# Altium schematic sheet 28Pins Schematic.SchDoc("28Pins Schematic") (continued)

## Library data of the parts
C1-C3 C5-C14 C16 C19 C20 C26-C28 = C2012X7R1H104K085AA: TDK - C2012X7R1H104K085AA - Keramikvielschichtkondensator, SMD, 0.1 µF, 50 V, 0805 [Metrisch 2012], ± 10%, X7R, C Series TDK; PCN Part Number: MLCC Part Number Change 30/Nov/2012; Product Training Modules: C Series General Applications SEAT, CCV, and TVCL Design Tools Guide to Replacing an Electrolytic Capacitor with an MLCC; Other Names: 445-7534-1; Online Catalog: C Series, General Purpose; Kits: 445-9015-KIT-ND  - KIT MLCC 1000PF-47UF 0805 10-50V; Capacitance: 0.1µF; Tolerance: ±10%; Thickness (Max): 0.037" (0.95mm); Temperature Coefficient: X7R; Supplier Part Number 1: 445-7534-1-ND; Supplier 1: Digi-Key; Stock 1: 148807; Standard Package: 1; Size / Dimension: 0.079" L x 0.049" W (2.00mm x 1.25mm); Series: C; RoHS Information: RoHS Certificate-MLCC; Ratings: -; Pricing 1: 1=0.17, 10=0.119, 100=0.0561, 500=0.0394, 1000=0.0326 (USD); Packaging: Cut Tape (CT); Package / Case: 0805 (2012 Metric); Operating Temperature: -55°C ~ 125°C; Mounting Type: Surface Mount, MLCC; Manufacturer Part Number: C2012X7R1H104K085AA; Manufacturer: TDK; Library Name: Arduino_V01.SchLib; Lead Style: -; Lead Spacing: -; Height - Seated (Max): -; Features: -; Family: Ceramic Capacitors; ComponentLink5URL: http://www.farnell.com/datasheets/1571323.pdf?src-supplier=Element14; ComponentLink5Description: http://www.farnell.com/datasheets/1571323.pdf?src-supplier=Element14; ComponentLink4URL: http://www.farnell.com/datasheets/1796654.pdf?src-supplier=Newark; ComponentLink4Description: http://www.farnell.com/datasheets/1796654.pdf?src-supplier=Newark; ComponentLink3URL: http://www.tdk.co.jp/tefe02/e412_c.pdf?src-supplier=Future+Electronics; ComponentLink3Description: http://www.tdk.co.jp/tefe02/e412_c.pdf?src-supplier=Future+Electronics; ComponentLink2URL: https://product.tdk.com/info/en/catalog/datasheets/mlcc_commercial_general_en.pdf?src-supplier=Digi-Key; ComponentLink2Description: https://product.tdk.com/info/en/catalog/datasheets/mlcc_commercial_general_en.pdf?src-supplier=Digi-Key; ComponentLink1URL: http://uk.rs-online.com/webdocs/1532/0900766b81532cd0.pdf?src-supplier=RSComponents; ComponentLink1Description: http://uk.rs-online.com/webdocs/1532/0900766b81532cd0.pdf?src-supplier=RSComponents; Component Kind: Standard; Category: Capacitors; Applications: General Purpose
  C5 C19 C26: Voltage - Rated: 50V
C4 C15 C17 C18 C23-C25 = CGA4J1X5R1C106M125AC: Automotive Grade MLCC, 0805, X5R, 16V, 10 F, 20%, 1.25mm; PCN Part Number: MLCC Part Number Change 30/Nov/2012; Manufacturer: TDK; Product Training Modules: CGA Series for Automotive Applications SEAT, CCV, and TVCL Design Tools Guide to Replacing an Electrolytic Capacitor with an MLCC; Other Names: 445-12654-1; Online Catalog: CGA Series, Automotive General, Mid and High Voltage; Featured Product: CGA Series MLCC Capacitors; EDA / CAD Models: Download from Accelerated Designs; Capacitance: 10µF; Tolerance: ±20%; Thickness (Max): 0.057" (1.45mm); Temperature Coefficient: X5R; Supplier Part Number 1: 445-12654-1-ND; Supplier 1: Digi-Key; Stock 1: 6740; Standard Package: 1; Size / Dimension: 0.079" L x 0.049" W (2.00mm x 1.25mm); Series: CGA; RoHS Information: CGA Series, RoHS Cert of Compliance; Ratings: AEC-Q200; Pricing 1: 1=0.52, 10=0.362, 100=0.2174, 500=0.163, 1000=0.1377 (USD); Packaging: Cut Tape (CT); Package / Case: 0805 (2012 Metric); Operating Temperature: -55°C ~ 85°C; Mounting Type: Surface Mount, MLCC; Manufacturer Part Number: CGA4J1X5R1C106M125AC; Library Name: Arduino_V01.SchLib; Family: Ceramic Capacitors; ComponentLink4URL: http://product.tdk.com/en/catalog/datasheets/mlcc_automotive_general_en.pdf?src-supplier=Avnet; ComponentLink4Description: http://product.tdk.com/en/catalog/datasheets/mlcc_automotive_general_en.pdf?src-supplier=Avnet; ComponentLink3URL: http://product.tdk.com/capacitor/mlcc/detailed_information.php?lang=en&ref=jp&part_no=CGA4J1X5R1C106M125AC&src-supplier=Mouser; ComponentLink3Description: http://product.tdk.com/capacitor/mlcc/detailed_information.php?lang=en&ref=jp&part_no=CGA4J1X5R1C106M125AC&src-supplier=Mouser; ComponentLink2URL: https://product.tdk.com/info/en/documents/chara_sheet/CGA4J1X5R1C106M125AC.pdf?src-supplier=Digi-Key; ComponentLink2Description: https://product.tdk.com/info/en/documents/chara_sheet/CGA4J1X5R1C106M125AC.pdf?src-supplier=Digi-Key; ComponentLink1URL: https://product.tdk.com/info/en/catalog/datasheets/mlcc_automotive_general_en.pdf?src-supplier=Digi-Key; ComponentLink1Description: https://product.tdk.com/info/en/catalog/datasheets/mlcc_automotive_general_en.pdf?src-supplier=Digi-Key; Component Kind: Standard; Category: Capacitors; Applications: Automotive
  C18 C23 C25: Voltage - Rated: 16V
C21 C22 C32 C33 = C0805C180J5GACTU: CAP CER 18PF 50V C0G/NP0 0805; Manufacturer: KEMET; Voltage: 50 Vdc; Tolerance: 5 %; Product Length: 2 mm; Product Height: 0.78 mm; Product Depth: 1.25 mm; Dielectric: C0G; Supplier Part Number 1: 399-1112-1-ND; Supplier 1: Digi-Key; Stock 1: 16285; Pricing 1: 1=0.14, 10=0.1, 100=0.0468, 500=0.0328, 1000=0.0272 (USD); Package/Case: 0805; Operating Temperature: -55 to 125 °C; Mounting: Surface Mount; Manufacturer Part Number: C0805C180J5GACTU; Library Name: Arduino_V01.SchLib; Construction: Flat; ComponentLink3URL: https://www.verical.com/server-webapp/html/getDatasheet?partID=759702&src-supplier=Verical; ComponentLink3Description: https://www.verical.com/server-webapp/html/getDatasheet?partID=759702&src-supplier=Verical; ComponentLink2URL: https://www.verical.com/server-webapp/html/getDatasheet?partID=385668&src-supplier=Verical; ComponentLink2Description: https://www.verical.com/server-webapp/html/getDatasheet?partID=385668&src-supplier=Verical; ComponentLink1URL: http://www.farnell.com/datasheets/1769375.pdf?src-supplier=Element14; ComponentLink1Description: http://www.farnell.com/datasheets/1769375.pdf?src-supplier=Element14; Component Kind: Standard; Category: Capacitor Ceramic Multilayer; Capacitance Value: 18 pF
C29 = CGA4J3X7R1E105K125AB: CAP CER 1UF 25V X7R 0805; PCN Part Number: MLCC Part Number Change 30/Nov/2012; Manufacturer: TDK; Tolerance: ±10%; Product Training Modules: CGA Series for Automotive Applications SEAT, CCV, and TVCL Design Tools Guide to Replacing an Electrolytic Capacitor with an MLCC; Other Names: 445-5687-6; Online Catalog: CGA Series, Automotive General, Mid and High Voltage; Featured Product: CGA Series MLCC Capacitors; Capacitance: 1µF; Thickness (Max): 0.057" (1.45mm); Temperature Coefficient: X7R; Supplier Part Number 1: 445-5687-1-ND; Supplier 1: Digi-Key; Stock 1: 9208; Standard Package: 1; Size / Dimension: 0.079" L x 0.049" W (2.00mm x 1.25mm); Series: CGA; RoHS Information: RoHS Certificate-MLCC; Ratings: AEC-Q200; Pricing 1: 1=0.24, 10=0.163, 100=0.0826, 500=0.0565, 1000=0.05 (USD); Packaging: Digi-Reel®; Package / Case: 0805 (2012 Metric); Operating Temperature: -55°C ~ 125°C; Mounting Type: Surface Mount, MLCC; Manufacturer Part Number: CGA4J3X7R1E105K125AB; Library Name: Arduino_V01.SchLib; Lead Style: -; Lead Spacing: -; Height - Seated (Max): -; Features: -; Family: Ceramic Capacitors; ComponentLink1URL: http://www.mouser.com/ds/2/400/lcc_automotive_general_en-843974.pdf?src-supplier=Mouser; ComponentLink1Description: http://www.mouser.com/ds/2/400/lcc_automotive_general_en-843974.pdf?src-supplier=Mouser; Component Kind: Standard; Category: Capacitors; Applications: Automotive
C30 C31 = EEE-1AA101WR: Cap Aluminum 100uF 10V 20% (5 X 5.4mm) SMD 50mA 1000 hr 85°C T/R; Manufacturer: Panasonic; Type: Can; Lifetime@Temperature: 2000 Hrs @ 85°C; Capacitance: 100 µF; Supplier Part Number 1: PCE3867CT-ND; Supplier 1: Digi-Key; Stock 1: 100201; Series: S; Ripple Current: 50 mA; Rated Voltage: 10 V; Pricing 1: 1=0.32, 10=0.221, 100=0.1328, 500=0.0996 (USD); Operating Temp Range: -40 to +85 °C; Operating Temp-Max: 85 °C; Manufacturer Part Number: EEE-1AA101WR; Library Name: Arduino_V01.SchLib; ComponentLink5URL: https://industrial.panasonic.com/cdbs/www-data/pdf/RDE0000/DME0000COL90.pdf?src-supplier=Verical; ComponentLink5Description: https://industrial.panasonic.com/cdbs/www-data/pdf/RDE0000/DME0000COL90.pdf?src-supplier=Verical; ComponentLink4URL: https://industrial.panasonic.com/cdbs/www-data/pdf/RDE0000/DME0000COL90.pdf?src-supplier=Allied+Electronics; ComponentLink4Description: https://industrial.panasonic.com/cdbs/www-data/pdf/RDE0000/DME0000COL90.pdf?src-supplier=Allied+Electronics; ComponentLink3URL: http://industrial.panasonic.com/www-data/pdf/ABA0000/ABA0000CE2.pdf?src-supplier=Future+Electronics; ComponentLink3Description: http://industrial.panasonic.com/www-data/pdf/ABA0000/ABA0000CE2.pdf?src-supplier=Future+Electronics; ComponentLink2URL: https://industrial.panasonic.com/cdbs/www-data/pdf/RDE0000/DME0000COL90.pdf?src-supplier=Mouser; ComponentLink2Description: https://industrial.panasonic.com/cdbs/www-data/pdf/RDE0000/DME0000COL90.pdf?src-supplier=Mouser; ComponentLink1URL: https://industrial.panasonic.com/cdbs/www-data/pdf/RDE0000/DME0000COL90.pdf?src-supplier=Avnet; ComponentLink1Description: https://industrial.panasonic.com/cdbs/www-data/pdf/RDE0000/DME0000COL90.pdf?src-supplier=Avnet; Component Kind: Standard; Case Size/Dimension: Ø 5 x 5.4 mm
D1 D3 = NSVBAT54HT1G: Schottky Diodes & Rectifiers SS SCHOTTKY DIODE; Manufacturer: ON Semiconductor; Voltage - Forward (Vf) (Max) @ If: 800mV @ 100mA; Voltage - DC Reverse (Vr) (Max): 30V; Speed: Small Signal =; Reverse Recovery Time (trr): 5ns; Current - Reverse Leakage @ Vr: 2µA @ 25V; Current - Average Rectified (Io): 200mA (DC); Capacitance @ Vr, F: 10pF @ 1V, 1MHz; Supplier Part Number 1: NSVBAT54HT1GOSCT-ND; Supplier Device Package: SOD-323; Supplier 1: Digi-Key; Stock 1: 10770; Standard Package: 3,000; Pricing 1: 1=0.48, 10=0.362, 25=0.3268, 100=0.1723, 250=0.1705, 500=0.1527, 1000=0.1188 (USD); Packaging: Tape & Reel (TR); Package / Case: SC-76, SOD-323; Mounting Type: Surface Mount; Manufacturer Part Number: NSVBAT54HT1G; Library Name: Arduino_V01.SchLib; Family: Diodes, Rectifiers - Single; Diode Type: Schottky; ComponentLink2URL: http://www.onsemi.com/pub_link/Collateral/BAT54HT1-D.PDF?src-supplier=Avnet+Europe; ComponentLink2Description: http://www.onsemi.com/pub_link/Collateral/BAT54HT1-D.PDF?src-supplier=Avnet+Europe; ComponentLink1URL: http://www.onsemi.com/pub/Collateral/BAT54HT1-D.PDF?src-supplier=Digi-Key; ComponentLink1Description: http://www.onsemi.com/pub/Collateral/BAT54HT1-D.PDF?src-supplier=Digi-Key; Component Kind: Standard; Category: Discrete Semiconductor Products
D2 D5 D6 = LOL29K-H2K1-24-Z: OSRAM - LO L29K-H2K1-24-Z - SMARTLED 0603 ORANGE; Manufacturer: Osram Opto; Wavelength - Peak: 610nm; Wavelength - Dominant: 606nm; Voltage - Forward (Vf) (Typ): 1.8V; Viewing Angle: 160°; Millicandela Rating: 6.3mcd; Lens Transparency: Diffused; Lens Style: Rectangle with Flat Top; Lens Size: 1.30mm x 0.80mm; Lens Color: White; Current - Test: 2mA; Configuration: Standard; Categories: Optoelectronics; Supplier Part Number 1: 475-2740-2-ND; Supplier Device Package: 0603; Supplier 1: Digi-Key; Stock 1: 0; Size / Dimension: 1.70mm L x 0.80mm W; Series: SmartLED; Part Status: Active; Packaging: Digi-Reel®; Package / Case: 0603 (1608 Metric); Mounting Type: Surface Mount; Manufacturer Part Number: LOL29K-H2K1-24-Z; Library Name: Arduino_V01.SchLib; Height (Max): 0.65mm; Features: -; ComponentLink2URL: https://www.verical.com/server-webapp/html/getDatasheet?partID=693885&src-supplier=Verical; ComponentLink2Description: https://www.verical.com/server-webapp/html/getDatasheet?partID=693885&src-supplier=Verical; ComponentLink1URL: https://dammedia.osram.info/media/resource/hires/osram-dam-2493882/LO%20L29K.pdf?src-supplier=Digi-Key; ComponentLink1Description: https://dammedia.osram.info/media/resource/hires/osram-dam-2493882/LO%20L29K.pdf?src-supplier=Digi-Key; Component Kind: Standard; Color: Orange
D4 = LGL29K-F2J1-24-Z: LED Uni-Color Green 572nm Automotive 2-Pin Chip 0603(1608Metric) T/R; Manufacturer: Osram Opto; Wavelength - Dominant: 570nm; Voltage - Forward (Vf) (Typ): 1.7V; Viewing Angle: 160°; Millicandela Rating: 3.5mcd; Lens Transparency: Diffused; Lens Style: Rectangle with Flat Top; Lens Size: 1.30mm x 0.80mm; Lens Color: White; Current - Test: 2mA; Configuration: Standard; Categories: Optoelectronics; Wavelength - Peak: 572nm; Supplier Part Number 1: 475-3118-1-ND; Supplier Device Package: 0603; Supplier 1: Digi-Key; Stock 1: 0; Size / Dimension: 1.70mm L x 0.80mm W; Series: SmartLED; Part Status: Active; Packaging: Digi-Reel®; Package / Case: 0603 (1608 Metric); Mounting Type: Surface Mount; Manufacturer Part Number: LGL29K-F2J1-24-Z; Library Name: Arduino_V01.SchLib; Height (Max): 0.65mm; Features: -; ComponentLink5URL: http://catalog.osram-os.com/catalogue/catalogue.do;jsessionid=3DE84243E85F820603DAFD5199D8DDA3?act=downloadFile&favOid=020000020000138e000100b6&src-supplier=Avnet+Europe; ComponentLink5Description: http://catalog.osram-os.com/catalogue/catalogue.do;jsessionid=3DE84243E85F820603DAFD5199D8DDA3?act=downloadFile&favOid=020000020000138e000100b6&src-supplier=Avnet+Europe; ComponentLink4URL: https://dammedia.osram.info/media/resource/hires/osram-dam-2493945/LG%20L29K.pdf?src-supplier=Digi-Key; ComponentLink4Description: https://dammedia.osram.info/media/resource/hires/osram-dam-2493945/LG%20L29K.pdf?src-supplier=Digi-Key; ComponentLink3URL: http://components.arrow.com/part/dataview/0323_02006818?src-supplier=Arrow+Europe; ComponentLink3Description: http://components.arrow.com/part/dataview/0323_02006818?src-supplier=Arrow+Europe; ComponentLink2URL: http://components.arrow.com/part/dataview/41971649S9781339N2128?src-supplier=Arrow; ComponentLink2Description: http://components.arrow.com/part/dataview/41971649S9781339N2128?src-supplier=Arrow; ComponentLink1URL: http://catalog.osram-os.com/catalogue/catalogue.do;jsessionid=3DE84243E85F820603DAFD5199D8DDA3?act=downloadFile&favOid=020000020000138e000100b6&src-supplier=Avnet; ComponentLink1Description: http://catalog.osram-os.com/catalogue/catalogue.do;jsessionid=3DE84243E85F820603DAFD5199D8DDA3?act=downloadFile&favOid=020000020000138e000100b6&src-supplier=Avnet; Component Kind: Standard; Color: Green
FB1-FB3 = MPZ2012S601AT000: FERRITE BEAD 600 OHM 0805 1LN; PCN Part Number: MMZ,MPS Series Oct/2014; Manufacturer: TDK; Product Training Modules: EMC Components; Other Names: 445-2206-6; Online Catalog: MPZ2012 Series; Impedance @ Frequency: 600 Ohm @ 100MHz; Filter Type: Differential Mode - Single; DC Resistance (DCR): 100 mOhm Max; Current Rating: 2A; Catalog Drawings: (MMZ,MPZ)2012 Series Top    (MMZ,MPZ)2012 Series Footprint    (MMZ,MPZ)2012 Series Side; Supplier Part Number 1: 445-2206-1-ND; Supplier 1: Digi-Key; Stock 1: 137805; Standard Package: 1; Size / Dimension: 0.079" L x 0.049" W (2.00mm x 1.25mm); Series: MPZ; Pricing 1: 1=0.11, 10=0.091, 25=0.0704, 50=0.0584, 100=0.0503, 250=0.0403, 500=0.0362, 1000=0.0302 (USD); Packaging: Digi-Reel®; Package / Case: 0805 (2012 Metric); Mounting Type: Surface Mount; Manufacturer Part Number: MPZ2012S601AT000; Library Name: Arduino_V01.SchLib; Height (Max): 0.041" (1.05mm); Family: Ferrite Beads and Chips; ComponentLink2URL: https://product.tdk.com/info/en/catalog/datasheets/beads_commercial_power_mpz2012_en.pdf?src-supplier=Digi-Key; ComponentLink2Description: https://product.tdk.com/info/en/catalog/datasheets/beads_commercial_power_mpz2012_en.pdf?src-supplier=Digi-Key; ComponentLink1URL: https://media.digikey.com/pdf/Data%20Sheets/TDK%20PDFs/MPZ_Series_Br.pdf?src-supplier=Digi-Key; ComponentLink1Description: https://media.digikey.com/pdf/Data%20Sheets/TDK%20PDFs/MPZ_Series_Br.pdf?src-supplier=Digi-Key; Component Kind: Standard; Category: Filters
FID1-FID4 = FID_1MM: Fiducial 40mil diameter, 120mil mask; Library Name: Arduino_V01.SchLib
J1 J7 = M20-7820842: 2.54mm (0.1") Pitch SIL Vertical PC Tail Socket Assembly, selective gold + tin, 8 contacts; Manufacturer: Harwin; Rad Hard: No; Number of Contacts: 8; Gender: SKT; Type: Socket Strip; Termination Method: Solder; Supplier Part Number 1: 952-1823-ND; Supplier 1: Digi-Key; Stock 1: 0; Pricing 1: 1=1.1, 10=0.972, 100=0.8382, 500=0.6858, 1000=0.6096, 5000=0.5334, 10000=0.5067 (USD); Pitch: 2.54 mm; Number of Rows: 1; Manufacturer Part Number: M20-7820842; Library Name: Arduino_V01.SchLib; Contact Plating: Gold; ComponentLink2URL: http://www.harwin.com/include/downloads/drawings/M20-782.PDF?src-supplier=Avnet; ComponentLink2Description: http://www.harwin.com/include/downloads/drawings/M20-782.PDF?src-supplier=Avnet; ComponentLink1URL: https://cdn.harwin.com/pdfs/Harwin_PC_Connectors_M20.pdf?src-supplier=Avnet+Europe; ComponentLink1Description: https://cdn.harwin.com/pdfs/Harwin_PC_Connectors_M20.pdf?src-supplier=Avnet+Europe; Component Kind: Standard; Category: Connector Headers and PCB Receptacles
J2 J3 = 67997-206HLF: CONN HEADER VERT 6POS 2.54MM; Manufacturer: Amphenol FCI; Voltage Rating: 1.5 kV; Number of Positions / Contacts: 6; Mounting Angle: Vertical; Mating Post Length: 5.84 mm; Insulation Resistance: 5000 MOhms; Current Rating: 3 A; Brand: FCI; Type: Unshrouded; Termination Style: Through Hole; Termination Post Length: 3.05 mm; Supplier Part Number 1: 609-3234-ND; Supplier 1: Digi-Key; Stock 1: 14300; Series: 67997; RoHS: Details; Product Type: Headers; Product Category: Headers & Wire Housings; Pricing 1: 1=0.33, 10=0.316, 100=0.2212, 500=0.1896, 1000=0.158, 5000=0.1355, 10000=0.1264 (USD); Pitch: 2.54 mm; Packaging: Bulk; Operating Temperature Range: - 65 C to + 125 C; Number of Rows: 2; Number of Positions: 6; Mounting Style: Through Hole; Manufacturer Part Number: 67997-206HLF; Library Name: Arduino_V01.SchLib; Housing Material: Thermoplastic; Flammability Rating: UL 94 V-0; Factory Pack Quantity: 100; Contact Plating: Gold/GTX; Contact Material: Phosphor Bronze; Contact Gender: Pin (Male); ComponentLink3URL: https://cdn.amphenol-icc.com/media/wysiwyg/files/drawing/67996.pdf?src-supplier=Digi-Key; ComponentLink3Description: https://cdn.amphenol-icc.com/media/wysiwyg/files/drawing/67996.pdf?src-supplier=Digi-Key; ComponentLink2URL: http://portal.fciconnect.com/Comergent/fci/drawing/67996.pdf?src-supplier=Avnet; ComponentLink2Description: http://portal.fciconnect.com/Comergent/fci/drawing/67996.pdf?src-supplier=Avnet; ComponentLink1URL: http://portal.fciconnect.com/Comergent/adirect/fci?cmd=catCustomerDrawing&productName=67997-206HLF&src-supplier=Mouser; ComponentLink1Description: http://portal.fciconnect.com/Comergent/adirect/fci?cmd=catCustomerDrawing&productName=67997-206HLF&src-supplier=Mouser; Component Kind: Standard
J4 = M20-7821046: HARWIN - M20-7821046 - Board-To-Board Connector, M20 Series, Through Hole, Receptacle, 10, 2.54 mm, Tin Plated Contacts; Number of Contacts: 10; Current Rating: 3A; Body Orientation: Straight; Type: Socket Strip; Termination Method: Solder; Supplier Part Number 1: 952-1847-ND; Supplier 1: Digi-Key; Stock 1: 499; Pricing 1: 1=1.28, 10=1.122, 100=0.968, 500=0.792, 1000=0.704, 5000=0.616, 10000=0.5852 (USD); Pitch: 2.54mm; Number of Rows: 1; Mounting Type: Through Hole; Manufacturer Part Number: M20-7821046; Manufacturer: Harwin; Library Name: Arduino_V01.SchLib; Contact Material: Phosphor Bronze; ComponentLink5URL: http://uk.rs-online.com/webdocs/1422/0900766b81422659.pdf?src-supplier=RSComponents; ComponentLink5Description: http://uk.rs-online.com/webdocs/1422/0900766b81422659.pdf?src-supplier=RSComponents; ComponentLink4URL: https://cdn.harwin.com/pdfs/Harwin_PC_Connectors_M20.pdf?src-supplier=Avnet+Europe; ComponentLink4Description: https://cdn.harwin.com/pdfs/Harwin_PC_Connectors_M20.pdf?src-supplier=Avnet+Europe; ComponentLink3URL: http://www.harwin.com/include/downloads/drawings/M20-782.PDF?src-supplier=Avnet; ComponentLink3Description: http://www.harwin.com/include/downloads/drawings/M20-782.PDF?src-supplier=Avnet; ComponentLink2URL: https://cdn.harwin.com/pdfs/M20-782.pdf?src-supplier=Digi-Key; ComponentLink2Description: https://cdn.harwin.com/pdfs/M20-782.pdf?src-supplier=Digi-Key; ComponentLink1URL: https://www.verical.com/server-webapp/html/getDatasheet?partID=559226&src-supplier=Verical; ComponentLink1Description: https://www.verical.com/server-webapp/html/getDatasheet?partID=559226&src-supplier=Verical; Component Kind: Standard
J5 = M20-7820642: Conn Socket Strip SKT 6 POS 2.54mm Solder ST Thru-Hole Tube; Manufacturer: Harwin; Number of Contacts: 6; Type: Socket Strip; Termination Method: Solder; Supplier Part Number 1: 952-1808-ND; Supplier 1: Digi-Key; Stock 1: 1120; Pricing 1: 1=0.99, 10=0.87, 100=0.7502, 500=0.6138, 1000=0.5456, 5000=0.4774, 10000=0.4535 (USD); Pitch: 2.54 mm; Number of Rows: 1; Manufacturer Part Number: M20-7820642; Library Name: Arduino_V01.SchLib; Gender: SKT; Contact Plating: Gold; ComponentLink4URL: http://components.arrow.com/part/dataview/0323_00725895?src-supplier=Arrow+Europe; ComponentLink4Description: http://components.arrow.com/part/dataview/0323_00725895?src-supplier=Arrow+Europe; ComponentLink3URL: http://www.harwin.com/include/downloads/pdfs/60PAGE140.PDF?src-supplier=Avnet; ComponentLink3Description: http://www.harwin.com/include/downloads/pdfs/60PAGE140.PDF?src-supplier=Avnet; ComponentLink2URL: https://cdn.harwin.com/pdfs/M20-782.pdf?src-supplier=Digi-Key; ComponentLink2Description: https://cdn.harwin.com/pdfs/M20-782.pdf?src-supplier=Digi-Key; ComponentLink1URL: http://www.mouser.com/ds/2/181/Harwin_Product_Catalog_page_197-1134272.pdf?src-supplier=Mouser; ComponentLink1Description: http://www.mouser.com/ds/2/181/Harwin_Product_Catalog_page_197-1134272.pdf?src-supplier=Mouser; Component Kind: Standard; Category: Connector Headers and PCB Receptacles
J6 J8 = PAD_WITH_HOLE_4MMx1.5MM: Library Name: Arduino_V01.SchLib; Description: PAD_WITH_HOLE_4MMx1.5MM
J9 = 68602-204HLF: CONN HEADER VERT 4POS 2.54MM; Manufacturer: Amphenol FCI; Rad Hard: No; Number of Contacts: 4; Type: Unshrouded Header; Termination Method: Solder; Supplier Part Number 1: 609-3369-ND; Supplier 1: Digi-Key; Stock 1: 655; Pricing 1: 1=0.34, 10=0.319, 100=0.2232, 500=0.1913, 1000=0.1594, 5000=0.1367, 10000=0.1275 (USD); Pitch: 2.54 mm; Packaging: Bulk; Number of Rows: 2; Manufacturer Part Number: 68602-204HLF; Library Name: Arduino_V01.SchLib; Contact Plating: Gold Over Nickel|GXT Over Nickel; ComponentLink1URL: https://cdn.amphenol-icc.com/media/wysiwyg/files/drawing/67996.pdf?src-supplier=Digi-Key; ComponentLink1Description: https://cdn.amphenol-icc.com/media/wysiwyg/files/drawing/67996.pdf?src-supplier=Digi-Key; Component Kind: Standard; Category: Connector Headers and PCB Receptacles
J10 = 10118193-0001LF: CONN USB MICRO B RECPT SMT R/A; Manufacturer: Amphenol FCI; Number of Contacts: 5 Contact; Mounting Angle: Right; Insulation Resistance: 1000 MOhms; Gender: Female; Current Rating: 1.8 A; Connector Type: Micro USB Type B receptacle; Brand: FCI; Termination Style: SMD/SMT; Supplier Part Number 1: 609-4616-1-ND; Supplier 1: Digi-Key; Stock 1: 50057; Standard: USB 2.0; RoHS: Details; Product Category: USB Connectors; Product: Micro USB Type B Connectors; Pricing 1: 1=0.43, 10=0.405, 25=0.3648, 50=0.3242, 100=0.3107, 250=0.2837, 500=0.2702 (USD); Packaging: Reel; Operating Temperature Range: - 55 C to + 85 C; Manufacturer Part Number: 10118193-0001LF; Library Name: Arduino_V01.SchLib; Flammability Rating: UL 94 V-0; Factory Pack Quantity: 1200; Contact Plating: Gold; Contact Material: Copper Alloy; ComponentLink6URL: https://cdn.amphenol-icc.com/media/wysiwyg/files/documentation/datasheet/inputoutput/io_usb_micro.pdf?src-supplier=Mouser; ComponentLink6Description: https://cdn.amphenol-icc.com/media/wysiwyg/files/documentation/datasheet/inputoutput/io_usb_micro.pdf?src-supplier=Mouser; ComponentLink5URL: https://cdn.amphenol-icc.com/media/wysiwyg/files/documentation/datasheet/inputoutput/io_usb_micro.pdf?src-supplier=Allied+Electronics; ComponentLink5Description: https://cdn.amphenol-icc.com/media/wysiwyg/files/documentation/datasheet/inputoutput/io_usb_micro.pdf?src-supplier=Allied+Electronics; ComponentLink4URL: https://cdn.amphenol-icc.com/media/wysiwyg/files/documentation/datasheet/inputoutput/io_usb_micro.pdf?src-supplier=Verical; ComponentLink4Description: https://cdn.amphenol-icc.com/media/wysiwyg/files/documentation/datasheet/inputoutput/io_usb_micro.pdf?src-supplier=Verical; ComponentLink3URL: http://www.amphenol-icc.com/media/wysiwyg/files/drawing/10118193.pdf?src-supplier=Digi-Key; ComponentLink3Description: http://www.amphenol-icc.com/media/wysiwyg/files/drawing/10118193.pdf?src-supplier=Digi-Key; ComponentLink2URL: https://cdn.amphenol-icc.com/media/wysiwyg/files/documentation/datasheet/inputoutput/io_usb_micro.pdf?src-supplier=Arrow; ComponentLink2Description: https://cdn.amphenol-icc.com/media/wysiwyg/files/documentation/datasheet/inputoutput/io_usb_micro.pdf?src-supplier=Arrow; ComponentLink1URL: https://cdn.amphenol-icc.com/media/wysiwyg/files/documentation/datasheet/inputoutput/io_usb_micro.pdf?src-supplier=Digi-Key; ComponentLink1Description: https://cdn.amphenol-icc.com/media/wysiwyg/files/documentation/datasheet/inputoutput/io_usb_micro.pdf?src-supplier=Digi-Key; Component Kind: Standard; Color: Black
JP1 JP3 = 68000-203HLF: CONN HEADER VERT 3POS 2.54MM; Manufacturer: Amphenol FCI; Voltage Rating: 1.5 kV; Number of Positions / Contacts: 3; Mounting Angle: Vertical; Mating Post Length: 5.84 mm; Insulation Resistance: 5000 MOhms; Current Rating: 3 A; Brand: FCI; Type: Unshrouded; Termination Style: Through Hole; Termination Post Length: 2.41 mm; Supplier Part Number 1: 609-3464-ND; Supplier 1: Digi-Key; Stock 1: 14338; Series: BergStik; RoHS: Details; Product Type: Headers; Product Category: Headers & Wire Housings; Pricing 1: 1=0.28, 10=0.267, 100=0.1869, 500=0.1602, 1000=0.1335, 5000=0.1145, 10000=0.1068 (USD); Pitch: 2.54 mm; Packaging: Bulk; Number of Rows: 1; Number of Positions: 3; Mounting Style: Through Hole; Manufacturer Part Number: 68000-203HLF; Library Name: Arduino_V01.SchLib; Housing Material: Thermoplastic; Factory Pack Quantity: 100; Contact Plating: Gold; Contact Material: Phosphor Bronze; Contact Gender: Pin (Male); ComponentLink5URL: http://portal.fciconnect.com/Comergent/fci/drawing/68000.pdf?src-supplier=Avnet; ComponentLink5Description: http://portal.fciconnect.com/Comergent/fci/drawing/68000.pdf?src-supplier=Avnet; ComponentLink4URL: https://cdn.amphenol-icc.com/media/wysiwyg/files/drawing/68000.pdf?src-supplier=Digi-Key; ComponentLink4Description: https://cdn.amphenol-icc.com/media/wysiwyg/files/drawing/68000.pdf?src-supplier=Digi-Key; ComponentLink3URL: http://portal.fciconnect.com/Comergent/fci/drawing/68000.pdf?src-supplier=Avnet+Europe; ComponentLink3Description: http://portal.fciconnect.com/Comergent/fci/drawing/68000.pdf?src-supplier=Avnet+Europe; ComponentLink2URL: http://www.farnell.com/datasheets/1914865.pdf?src-supplier=Element14; ComponentLink2Description: http://www.farnell.com/datasheets/1914865.pdf?src-supplier=Element14; ComponentLink1URL: http://www.mouser.com/ds/2/154/68000-50221.pdf?src-supplier=Mouser; ComponentLink1Description: http://www.mouser.com/ds/2/154/68000-50221.pdf?src-supplier=Mouser; Component Kind: Standard
JP2 = 68001-204HLF: CONN HEADER VERT 4POS 2.54MM; Manufacturer: Amphenol FCI; Rad Hard: No; Number of Contacts: 4; Gender: HDR; Type: Unshrouded Header; Termination Method: Solder; Supplier Part Number 1: 609-3402-ND; Supplier 1: Digi-Key; Stock 1: 3841; Pricing 1: 1=0.38, 10=0.357, 100=0.2501, 500=0.2144, 1000=0.1787, 5000=0.1532, 10000=0.1429 (USD); Pitch: 2.54 mm; Packaging: Bulk; Number of Rows: 1; Manufacturer Part Number: 68001-204HLF; Library Name: Arduino_V01.SchLib; Contact Plating: Gold Over Nickel|GXT Over Nickel; ComponentLink2URL: https://cdn.amphenol-icc.com/media/wysiwyg/files/drawing/68000.pdf?src-supplier=Mouser; ComponentLink2Description: https://cdn.amphenol-icc.com/media/wysiwyg/files/drawing/68000.pdf?src-supplier=Mouser; ComponentLink1URL: https://cdn.amphenol-icc.com/media/wysiwyg/files/drawing/68000.pdf?src-supplier=Digi-Key; ComponentLink1Description: https://cdn.amphenol-icc.com/media/wysiwyg/files/drawing/68000.pdf?src-supplier=Digi-Key; Component Kind: Standard; Category: Connector Headers and PCB Receptacles
L1 = L1210R2R2MDWIT: FIXED IND 2.2UH 2A 80 MOHM SMD; Manufacturer: KEMET; Type: Chip; Tolerance: 20 %; Rad Hard: No; Product Height: 2.7 mm; Maximum DC Resistance: 80(Typ) mOhm; Maximum DC Current: 1.13 A; Supplier Part Number 1: 399-9598-1-ND; Supplier 1: Digi-Key; Stock 1: 17969; Product Depth: 2.7 mm; Pricing 1: 1=0.55, 10=0.479, 100=0.3421, 500=0.2874 (USD); Package/Case: 1210; Manufacturer Part Number: L1210R2R2MDWIT; Library Name: Arduino_V01.SchLib; Inductance: 2.2 uH; ComponentLink5URL: http://www.kemet.com/Lists/ProductCatalog/Attachments/57/F3115.pdf?src-supplier=Avnet; ComponentLink5Description: http://www.kemet.com/Lists/ProductCatalog/Attachments/57/F3115.pdf?src-supplier=Avnet; ComponentLink4URL: https://api.kemet.com/component-edge/download/datasheet/L1210R2R2MDWIT.pdf?src-supplier=Digi-Key; ComponentLink4Description: https://api.kemet.com/component-edge/download/datasheet/L1210R2R2MDWIT.pdf?src-supplier=Digi-Key; ComponentLink3URL: http://www.farnell.com/datasheets/27262.pdf?src-supplier=Element14; ComponentLink3Description: http://www.farnell.com/datasheets/27262.pdf?src-supplier=Element14; ComponentLink2URL: https://content.kemet.com/datasheets/F3115.pdf?src-supplier=Avnet+Europe; ComponentLink2Description: https://content.kemet.com/datasheets/F3115.pdf?src-supplier=Avnet+Europe; ComponentLink1URL: https://content.kemet.com/datasheets/F3115.pdf?src-supplier=Mouser; ComponentLink1Description: https://content.kemet.com/datasheets/F3115.pdf?src-supplier=Mouser; Component Kind: Standard
LINK1-LINK3 = QPC02SXGN-RC: CONN JUMPER SHORTING .100" GOLD; Manufacturer: Sullins; Pitch: 0.100" (2.54mm); Other Names: S9337; Number of Positions or Pins (Grid): 2 (1 x 2); Gender: Female Sockets; Dynamic Catalog: Shunts and Jumpers - Sullins Connector Solutions; Type: Open Top; Supplier Part Number 1: S9337-ND; Supplier 1: Digi-Key; Stock 1: 547968; Standard Package: 2,000; Pricing 1: 1=0.1, 10=0.059, 25=0.044, 50=0.0378, 100=0.0365, 250=0.0315, 500=0.0302, 1000=0.0265, 2500=0.0239 (USD); Packaging: Bulk; Manufacturer Part Number: QPC02SXGN-RC; Library Name: Arduino_V01.SchLib; Height: 0.235" (5.97mm); Family: Shunts, Jumpers; Contact Finish Thickness: Flash; Contact Finish: Gold; ComponentLink1URL: https://s3.amazonaws.com/catalogspreads-pdf/PAGE128-129%20.100%20JUMPER.pdf?src-supplier=Digi-Key; ComponentLink1Description: https://s3.amazonaws.com/catalogspreads-pdf/PAGE128-129%20.100%20JUMPER.pdf?src-supplier=Digi-Key; Category: Connectors, Interconnects
MH1-MH3 = MH_7.4MMC_3.2MMD: Mounting hole 7.4mm diameter, 3.2mm drill; Library Name: Arduino_V01.SchLib
MH4 = MH_7.4MMNC_3: Mounting hole 7.4mm diameter (non copper) 3.2mm drill NPTH; Library Name: Arduino_V01.SchLib
R1 R11 R13 R26 R28 = RC0805FR-07560RL: Surface Mount Thick Film Resistor, RC Series, 560 ohm, 125 mW, - 1%, 150 V, 0805 [2012 Metric]; Manufacturer: Yageo; Voltage Rating: 150 V; Unit Weight: 0.000194 oz; Temperature Coefficient: 100 PPM / C; Resistance: 560 Ohms; Power Rating: 125 mW (1/8 W); Width: 1.25 mm; Type: General Purpose Chip Resistor Surface Mount; Tolerance: 1 %; Termination Style: SMD/SMT; Supplier Part Number 1: 311-560CRCT-ND; Supplier 1: Digi-Key; Stock 1: 290660; Series: RC; RoHS: True; Product Category: Thick Film Resistors; Product: Thick Film Resistors General Purpose; Pricing 1: 1=0.1, 10=0.04, 100=0.0163, 1000=0.0073, 2500=0.0064 (USD); Packaging: Reel; Package / Case: 0805 (2012 metric); Operating Temperature Range: - 55 C to + 155 C; Manufacturer Part Number: RC0805FR-07560RL; Library Name: Arduino_V01.SchLib; Length: 2 mm; Height: 0.5 mm; ComponentLink3URL: http://www.farnell.com/datasheets/2167052.pdf?src-supplier=Element14; ComponentLink3Description: http://www.farnell.com/datasheets/2167052.pdf?src-supplier=Element14; ComponentLink2URL: http://www.yageo.com/documents/recent/PYu-RC_Group_51_RoHS_L_9.pdf?src-supplier=Mouser; ComponentLink2Description: http://www.yageo.com/documents/recent/PYu-RC_Group_51_RoHS_L_9.pdf?src-supplier=Mouser; ComponentLink1URL: http://www.yageo.com/documents/recent/PYu-RC_Group_51_RoHS_L_10.pdf?src-supplier=Digi-Key; ComponentLink1Description: http://www.yageo.com/documents/recent/PYu-RC_Group_51_RoHS_L_10.pdf?src-supplier=Digi-Key; Component Kind: Standard; Case Code - mm: 2012; Case Code - in: 0805; Brand: Yageo
R2 R7-R9 R15 R20 = RC0805FR-0710KL: RES SMD 10K OHM 1% 1/8W 0805; Manufacturer: Yageo; Voltage Rating: 150 V; Temperature Coefficient: 100 PPM / C; Resistance: 10 kOhms; Power Rating: 125 mW (1/8 W); Tolerance: 1 %; Termination Style: SMD/SMT; Supplier Part Number 1: 311-10.0KCRCT-ND; Supplier 1: Digi-Key; Stock 1: 24193550; Series: RC; Product: Thick Film Resistors General Purpose; Pricing 1: 1=0.1, 10=0.04, 100=0.0163, 1000=0.0073, 2500=0.0064 (USD); Packaging: Reel; Operating Temperature Range: - 55 C to + 155 C; Manufacturer Part Number: RC0805FR-0710KL; Library Name: Arduino_V01.SchLib; ComponentLink4URL: http://www.yageo.ru/pdf/RC0805.pdf?src-supplier=Future+Electronics; ComponentLink4Description: http://www.yageo.ru/pdf/RC0805.pdf?src-supplier=Future+Electronics; ComponentLink3URL: http://www.yageo.com/documents/recent/PYu-RC_Group_51_RoHS_L_10.pdf?src-supplier=Digi-Key; ComponentLink3Description: http://www.yageo.com/documents/recent/PYu-RC_Group_51_RoHS_L_10.pdf?src-supplier=Digi-Key; ComponentLink2URL: http://www.farnell.com/datasheets/2167052.pdf?src-supplier=Element14; ComponentLink2Description: http://www.farnell.com/datasheets/2167052.pdf?src-supplier=Element14; ComponentLink1URL: http://www.yageo.com/documents/recent/PYu-RC_Group_51_RoHS_L_9.pdf?src-supplier=Mouser; ComponentLink1Description: http://www.yageo.com/documents/recent/PYu-RC_Group_51_RoHS_L_9.pdf?src-supplier=Mouser; Component Kind: Standard; Case Code - mm: 2012; Case Code - in: 0805
R3 R17 R18 = RC0805FR-071KL: RESISTOR, 0805 1K 1%, FULL REEL; Product Range:YAGEO - RC Series; Resistance:1kohm; Power Rating:125mW; Resistance Tolerance:± 1%; Resistor Case Style:0805 [2012 Metric]; Resistor Element Material:Thick Film; Temperature Coefficient:± 250ppm/°C; Voltage Rating:150V; Packaging:Tape & Reel; MSL:-; SVHC:No SVHC (16-Dec-2013); External Depth:0.55mm; External Length / Height:2mm; External Width:1.25mm; No. of Pins:2; Operating Temperature Max:155°C; Operating Temperature Min:-55°C; Operating Temperature Range:-55°C to +155°C; Reel Quantity:5000; Resistor Mounting:SMD; Series:RC12H; Temperature Coef; Manufacturer: Yageo; Voltage Rating: 150 V; Temperature Coefficient: 100 PPM / C; Resistance: 1 kOhms; Power Rating: 125 mW (1/8 W); Tolerance: 1 %; Termination Style: SMD/SMT; Supplier Part Number 1: 311-1.00KCRCT-ND; Supplier 1: Digi-Key; Stock 1: 21029756; Series: RC; Product: Thick Film Resistors General Purpose; Pricing 1: 1=0.1, 10=0.04, 100=0.0163, 1000=0.0073, 2500=0.0064 (USD); Packaging: Reel; Operating Temperature Range: - 55 C to + 155 C; Manufacturer Part Number: RC0805FR-071KL; Library Name: Arduino_V01.SchLib; ComponentLink4URL: http://www.farnell.com/datasheets/2167052.pdf?src-supplier=Element14; ComponentLink4Description: http://www.farnell.com/datasheets/2167052.pdf?src-supplier=Element14; ComponentLink3URL: http://www.yageo.ru/pdf/RC0805.pdf?src-supplier=Future+Electronics; ComponentLink3Description: http://www.yageo.ru/pdf/RC0805.pdf?src-supplier=Future+Electronics; ComponentLink2URL: http://www.farnell.com/datasheets/1686128.pdf?src-supplier=Element14; ComponentLink2Description: http://www.farnell.com/datasheets/1686128.pdf?src-supplier=Element14; ComponentLink1URL: http://www.yageo.com/documents/recent/PYu-RC_Group_51_RoHS_L_10.pdf?src-supplier=Digi-Key; ComponentLink1Description: http://www.yageo.com/documents/recent/PYu-RC_Group_51_RoHS_L_10.pdf?src-supplier=Digi-Key; Component Kind: Standard; Case Code - mm: 2012; Case Code - in: 0805
R4 R10 R12 R14 R21-R23 = AC0805FR-0722RL: RES SMD 22 OHM 1% 1/8W 0805; Manufacturer: Yageo; Voltage Rating: 150 V; Unit Weight: 0.000194 oz; Temperature Coefficient: 100 PPM / C; Resistance: 22 Ohms; Power Rating: 125 mW (1/8 W); Width: 1.25 mm; Type: Chip Resistor Surface Mount; Tolerance: 1 %; Termination Style: SMD/SMT; Supplier Part Number 1: YAG3713CT-ND; Supplier 1: Digi-Key; Stock 1: 1095; Series: AC; RoHS: True; Product Category: Thick Film Resistors; Product: Thick Film Resistors SMD; Pricing 1: 1=0.1, 10=0.048, 100=0.0197, 1000=0.0089, 2500=0.0077 (USD); Packaging: Reel; Package / Case: 0805 (2012 metric); Operating Temperature Range: - 55 C to + 155 C; Manufacturer Part Number: AC0805FR-0722RL; Library Name: Arduino_V01.SchLib; Length: 2 mm; Height: 0.5 mm; ComponentLink1URL: http://www.yageo.com/NewPortal/yageodocoutput?fileName=/pdf/R-Chip/PYu-AC_51_RoHS_L_6.pdf&src-supplier=Mouser; ComponentLink1Description: http://www.yageo.com/NewPortal/yageodocoutput?fileName=/pdf/R-Chip/PYu-AC_51_RoHS_L_6.pdf&src-supplier=Mouser; Component Kind: Standard; Case Code - mm: 2012; Case Code - in: 0805; Brand: Yageo
R5 R6 R19 R27 = RC0805JR-070RL: Surface Mount Thick Film Resistor, RC Series, 125 mW, - 5%, 150 V, 0805 [2012 Metric]; Manufacturer: Yageo; Voltage Rating: 150 V; Temperature Coefficient: 200 PPM / C; Resistance: 0 Ohms; Power Rating: 125 mW (1/8 W); Tolerance: 5 %; Termination Style: SMD/SMT; Supplier Part Number 1: 311-0.0ARCT-ND; Supplier 1: Digi-Key; Stock 1: 9439861; Series: RC; Product: Thick Film Resistors Zero-Ohm; Pricing 1: 1=0.1, 10=0.035, 25=0.0248, 50=0.019, 100=0.014, 250=0.0107, 500=0.0086, 1000=0.0063 (USD); Packaging: Reel; Operating Temperature Range: - 55 C to + 155 C; Manufacturer Part Number: RC0805JR-070RL; Library Name: Arduino_V01.SchLib; ComponentLink3URL: https://www.verical.com/server-webapp/html/getDatasheet?partID=330685&src-supplier=Verical; ComponentLink3Description: https://www.verical.com/server-webapp/html/getDatasheet?partID=330685&src-supplier=Verical; ComponentLink2URL: http://documents.rs-components.com/techlib://itc_doclist_GB.htm?stockno=6185129&src-supplier=RSComponents; ComponentLink2Description: http://documents.rs-components.com/techlib://itc_doclist_GB.htm?stockno=6185129&src-supplier=RSComponents; ComponentLink1URL: http://www.farnell.com/datasheets/2167052.pdf?src-supplier=Element14; ComponentLink1Description: http://www.farnell.com/datasheets/2167052.pdf?src-supplier=Element14; Component Kind: Standard; Case Code - mm: 2012; Case Code - in: 0805
R16 R25 = RC0805FR-071ML: RES SMD 1M OHM 1% 1/8W 0805; Manufacturer: Yageo; Voltage Rating: 150 V; Temperature Coefficient: 100 PPM / C; Resistance: 1 MOhms; Power Rating: 125 mW (1/8 W); Tolerance: 1 %; Termination Style: SMD/SMT; Supplier Part Number 1: 311-1.00MCRCT-ND; Supplier 1: Digi-Key; Stock 1: 3833692; Series: RC; Product: Thick Film Resistors General Purpose; Pricing 1: 1=0.1, 10=0.04, 100=0.0163, 1000=0.0073, 2500=0.0064 (USD); Packaging: Reel; Operating Temperature Range: - 55 C to + 155 C; Manufacturer Part Number: RC0805FR-071ML; Library Name: Arduino_V01.SchLib; ComponentLink3URL: http://www.yageo.com/documents/recent/PYu-RC_Group_51_RoHS_L_9.pdf?src-supplier=Mouser; ComponentLink3Description: http://www.yageo.com/documents/recent/PYu-RC_Group_51_RoHS_L_9.pdf?src-supplier=Mouser; ComponentLink2URL: http://www.yageo.ru/pdf/RC0805.pdf?src-supplier=Future+Electronics; ComponentLink2Description: http://www.yageo.ru/pdf/RC0805.pdf?src-supplier=Future+Electronics; ComponentLink1URL: http://www.yageo.com/documents/recent/PYu-RC_Group_51_RoHS_L_10.pdf?src-supplier=Digi-Key; ComponentLink1Description: http://www.yageo.com/documents/recent/PYu-RC_Group_51_RoHS_L_10.pdf?src-supplier=Digi-Key; Component Kind: Standard; Case Code - mm: 2012; Case Code - in: 0805
R24 = RC0805FR-07100KL: YAGEO         RC0805FR-07100KL            RES, THICK FILM, 100K, 1%, 0.125W, 0805, REEL; Voltage Rating: 150 V; Temperature Coefficient: 100 PPM / C; Resistance: 100 kOhms; Power Rating: 125 mW (1/8 W); Tolerance: 1 %; Termination Style: SMD/SMT; Supplier Part Number 1: 311-100KCRDKR-ND; Supplier 1: Digi-Key; Stock 1: 5277424; Series: RC; Product: Thick Film Resistors General Purpose; Pricing 1: 1=0.1, 10=0.04, 100=0.0163, 1000=0.0073, 2500=0.0064 (USD); Packaging: Reel; Operating Temperature Range: - 55 C to + 155 C; Manufacturer Part Number: RC0805FR-07100KL; Manufacturer: Yageo; Library Name: Arduino_V01.SchLib; ComponentLink8URL: http://www.farnell.com/datasheets/444164.pdf?src-supplier=Element14; ComponentLink8Description: http://www.farnell.com/datasheets/444164.pdf?src-supplier=Element14; ComponentLink7URL: http://www.farnell.com/datasheets/1686128.pdf?src-supplier=Element14; ComponentLink7Description: http://www.farnell.com/datasheets/1686128.pdf?src-supplier=Element14; ComponentLink6URL: http://www.farnell.com/datasheets/2167052.pdf?src-supplier=Element14; ComponentLink6Description: http://www.farnell.com/datasheets/2167052.pdf?src-supplier=Element14; ComponentLink5URL: http://www.farnell.com/datasheets/38627.pdf?src-supplier=Element14; ComponentLink5Description: http://www.farnell.com/datasheets/38627.pdf?src-supplier=Element14; ComponentLink4URL: http://www.yageo.ru/pdf/RC0805.pdf?src-supplier=Future+Electronics; ComponentLink4Description: http://www.yageo.ru/pdf/RC0805.pdf?src-supplier=Future+Electronics; ComponentLink3URL: http://documents.rs-components.com/techlib://itc_doclist_GB.htm?stockno=6185113&src-supplier=RSComponents; ComponentLink3Description: http://documents.rs-components.com/techlib://itc_doclist_GB.htm?stockno=6185113&src-supplier=RSComponents; ComponentLink2URL: http://www.yageo.com/documents/recent/PYu-RC_Group_51_RoHS_L_9.pdf?src-supplier=Mouser; ComponentLink2Description: http://www.yageo.com/documents/recent/PYu-RC_Group_51_RoHS_L_9.pdf?src-supplier=Mouser; ComponentLink1URL: http://www.yageo.com/documents/recent/PYu-RC_Group_51_RoHS_L_10.pdf?src-supplier=Digi-Key; ComponentLink1Description: http://www.yageo.com/documents/recent/PYu-RC_Group_51_RoHS_L_10.pdf?src-supplier=Digi-Key; Component Kind: Standard; Case Code - mm: 2012; Case Code - in: 0805
SCKT1 = 4828-3004-CP: CONN IC DIP SOCKET 28POS TIN; Part # Aliases: 05111116010 80000917973; Manufacturer: 3M; Pitch: 2.54 mm; Number of Positions / Contacts: 28; Insulation Resistance: 5000 MOhms; Brand: 3M Electronic Solutions Division; Termination Style: Solder Tail; Supplier Part Number 1: 3M5480-ND; Supplier 1: Digi-Key; Stock 1: 5236; Socket / Case Type: DIP; RoHS: Details; Product Category: .100" Wire Mount Connectors; Product: DIP / SIP Sockets; Pricing 1: 1=0.79, 10=0.693, 25=0.6524, 50=0.6254, 100=0.5982, 250=0.5438, 500=0.4894, 1000=0.435, 2500=0.3943 (USD); Operating Temperature Range: - 25 C to + 85 C; Number of Rows: 2; Number of Positions: 28; Mounting Style: Through Hole; Manufacturer Part Number: 4828-3004-CP; Library Name: Arduino_V01.SchLib; Factory Pack Quantity: 5600; Contact Plating: Tin; ComponentLink1URL: http://multimedia.3m.com/mws/media/218496O/3mtm-dip-socket-4800-series-ts1099.pdf?src-supplier=Digi-Key; ComponentLink1Description: http://multimedia.3m.com/mws/media/218496O/3mtm-dip-socket-4800-series-ts1099.pdf?src-supplier=Digi-Key; Component Kind: Standard
SW1 SW2 = 1825910-6: ALCOSWITCH - TE CONNECTIVITY - 1825910-6 - Tactile Switch, FSMJ Series, Top Actuated, Through Hole, Round Button, 160 gf, 50mA at 24VDC; Supplier Part Number 1: 450-1650-ND; Supplier 1: Digi-Key; Stock 1: 10691; Pricing 1: 1=0.1, 10=0.101, 25=0.0956, 100=0.087, 250=0.0818, 500=0.0748, 1000=0.0627, 2500=0.0609, 5000=0.0574 (USD); Manufacturer Part Number: 1825910-6; Manufacturer: ALCOSWITCH - TE CONNECTIVITY; Library Name: Arduino_V01.SchLib; Component Kind: Standard
U1-U4 = SN74AHC1G09DBVR: TEXAS INSTRUMENTS - SN74AHC1G09DBVR - AND Gate, 74AHC1G09, 2 Input, 2 V to 5.5 V, SOT-23-5; Voltage - Supply: 2 V ~ 5.5 V; Other Names: 296-29202-6; Number of Inputs: 2; Number of Circuits: 1; Max Propagation Delay @ V, Max CL: 7.5ns @ 5V, 50pF; Logic Level - Low: 0.5 V ~ 1.65 V; Logic Level - High: 1.5 V ~ 3.85 V; Dynamic Catalog: 74AHC; Current - Quiescent (Max): 1µA; Current - Output High, Low: -, 8mA; Supplier Part Number 1: 296-29202-1-ND; Supplier Device Package: SOT-23-5; Supplier 1: Digi-Key; Stock 1: 19126; Standard Package: 1; Series: 74AHC; Pricing 1: 1=0.32, 10=0.24, 25=0.21, 100=0.1359, 250=0.1125, 500=0.09, 1000=0.069 (USD); Packaging: Digi-Reel®; Package / Case: SC-74A, SOT-753; Operating Temperature: -55°C ~ 125°C; Mounting Type: Surface Mount; Manufacturer Part Number: SN74AHC1G09DBVR; Manufacturer: Texas Instruments; Logic Type: AND Gate; Library Name: Arduino_V01.SchLib; Features: Open Drain; Family: Logic - Gates and Inverters; ComponentLink1URL: http://www.ti.com/lit/ds/symlink/sn74ahc1g09.pdf?src-supplier=Digi-Key; ComponentLink1Description: http://www.ti.com/lit/ds/symlink/sn74ahc1g09.pdf?src-supplier=Digi-Key; Component Kind: Standard; Category: Integrated Circuits (ICs)
U5 = ATMEGA328P-PU: ATMEGA328P-PU; 8 bit Microcontroller AVR 20MHz 1; 32kb Flash; 2kb RAM; I2C SPI 2; Manufacturer: Microchip / Atmel; Manuf. Code: ATM; Supply voltage (min.): 1.8 V; Supply voltage (max.): 5.5 V; Series (embedded microcontroller): AVR® ATmega; RAM size: 2 K x 8; Program memory size: 32 KB (16 K x 16); Peripherals: Brown-out Detect/Reset, POR, PWM, WDT; Oscillator type: Internal; I/O number: 23; EEPROM size: 1 K x 8; Data converter (embedded microcontroller): A/D 6x10b; Core size: 8-Bit; Connectivity: I²C, SPI, UART/USART; Casing: PDIP 28; Type: ATMEGA328P-PU; Supplier Part Number 1: ATMEGA328P-PU-ND; Supplier 1: Digi-Key; Stock 1: 1556; Program memory type: FLASH; Processor core: AVR; Pricing 1: 1=2.08, 25=1.91, 100=1.73 (USD); Operating temperature (min.): -40 °C; Max. operating temperature: +85 °C; Manufacturer Part Number: ATMEGA328P-PU; Library Name: Arduino_V01.SchLib; ComponentLink6URL: http://www.produktinfo.conrad.com/datenblaetter/150000-174999/155197-da-01-en-MICROCONTR_ATMEGA328P_PU_8BIT_PDIP_28.pdf?src-supplier=Conrad; ComponentLink6Description: http://www.produktinfo.conrad.com/datenblaetter/150000-174999/155197-da-01-en-MICROCONTR_ATMEGA328P_PU_8BIT_PDIP_28.pdf?src-supplier=Conrad; ComponentLink5URL: http://datasheet.iiic.cc/atmel/ATMEGA328P-PU.pdf?src-supplier=IIIC; ComponentLink5Description: http://datasheet.iiic.cc/atmel/ATMEGA328P-PU.pdf?src-supplier=IIIC; ComponentLink4URL: http://www.microchip.com/mymicrochip/filehandler.aspx?ddocname=en589968&src-supplier=Jameco; ComponentLink4Description: http://www.microchip.com/mymicrochip/filehandler.aspx?ddocname=en589968&src-supplier=Jameco; ComponentLink3URL: http://www.microchip.com/mymicrochip/filehandler.aspx?ddocname=en589968&src-supplier=Verical; ComponentLink3Description: http://www.microchip.com/mymicrochip/filehandler.aspx?ddocname=en589968&src-supplier=Verical; ComponentLink2URL: http://www.rapidonline.com/pdf/73-4283.pdf?src-supplier=Rapid+Electronics; ComponentLink2Description: http://www.rapidonline.com/pdf/73-4283.pdf?src-supplier=Rapid+Electronics; ComponentLink1URL: http://www.farnell.com/datasheets/2047852.pdf?src-supplier=Element14; ComponentLink1Description: http://www.farnell.com/datasheets/2047852.pdf?src-supplier=Element14; Component Kind: Standard; Clock speed: 20 MHz
U6 = TL1963A-33DCQT: Single Output LDO, 1.5A, 3.3V Fast Trans; Manufacturer: Texas Instruments; Voltage - Input: Up to 20V; Voltage - Dropout (Typical): 0.34V @ 1.5A; Regulator Topology: Positive Fixed; Other Names: 296-32342-2 TL1963A-33DCQT-ND TL1963A33DCQT; Online Catalog: 3.1V to 3.3V; Number of Regulators: 1; EDA / CAD Models: Download from Accelerated Designs; Current - Limit (Min): 1.6A; Voltage - Output: 3.3V; Supplier Part Number 1: 296-32342-1-ND; Supplier Device Package: SOT-223-6; Supplier 1: Digi-Key; Stock 1: 288; Standard Package: 250; Series: -; Pricing 1: 1=3.15, 10=2.827, 25=2.6732, 100=2.1978 (USD); Packaging: Tape & Reel (TR); Package / Case: SOT-223-6; Operating Temperature: -40°C ~ 125°C; Mounting Type: Surface Mount; Manufacturer Part Number: TL1963A-33DCQT; Library Name: Arduino_V01.SchLib; Family: PMIC - Voltage Regulators - Linear; Current - Output: 1.5A; ComponentLink3URL: http://focus.ti.com/lit/ds/symlink/tl1963a-25.pdf?src-supplier=Avnet+Europe; ComponentLink3Description: http://focus.ti.com/lit/ds/symlink/tl1963a-25.pdf?src-supplier=Avnet+Europe; ComponentLink2URL: http://www.ti.com/lit/ds/symlink/tl1963a.pdf?src-supplier=Digi-Key; ComponentLink2Description: http://www.ti.com/lit/ds/symlink/tl1963a.pdf?src-supplier=Digi-Key; ComponentLink1URL: http://www.ti.com/general/docs/lit/getliterature.tsp?genericPartNumber=TL1963A-18&&fileType=pdf&src-supplier=Avnet; ComponentLink1Description: http://www.ti.com/general/docs/lit/getliterature.tsp?genericPartNumber=TL1963A-18&&fileType=pdf&src-supplier=Avnet; Component Kind: Standard; Category: Integrated Circuits (ICs)
U7 = ATMEGA16U2-AU: MICROCHIP - ATMEGA16U2-AU - MCU, 8BIT, MEGAAVR, 16MHZ, TQFP-32; Manufacturer: Microchip / Atmel; SVHC: No SVHC (15-Jun-2015); Supply Voltage Min: 2.7V; Supply Voltage Max: 5.5V; RAM Memory Size: 512Byte; Program Memory Size: 16KB; No. of Pins: 32Pins; No. of I/O's: 22I/O's; MCU Case Style: TQFP; MCU Applications: USB Microcontroller; Embedded Interface Type: QSPI, UART, USB; Supplier Part Number 1: ATMEGA16U2-AU-ND; Supplier 1: Digi-Key; Stock 1: 1546; Pricing 1: 1=2.45, 25=2.25, 100=2.04 (USD); Packaging: Each; MSL: MSL 3 - 168 hours; MCU Core Size: 8bit; Manufacturer Part Number: ATMEGA16U2-AU; Library Name: Arduino_V01.SchLib; CPU Speed: 16MHz; ComponentLink2URL: http://www.atmel.com/dyn/resources/prod_documents/doc7799.pdf?src-supplier=Farnell; ComponentLink2Description: http://www.atmel.com/dyn/resources/prod_documents/doc7799.pdf?src-supplier=Farnell; ComponentLink1URL: http://www.microchip.com/mymicrochip/filehandler.aspx?ddocname=en590463&src-supplier=Mouser; ComponentLink1Description: http://www.microchip.com/mymicrochip/filehandler.aspx?ddocname=en590463&src-supplier=Mouser; Component Kind: Standard; Architecture: megaAVR
Y1 Y2 = ABM7-16.000MHZ-D2Y-T: CRYSTAL 16.0000MHZ 18PF SMD; Manufacturer: Abracon; Other Names: 535-9840-2  ABM7-16.000MHZ-D-2-Y-T  ABM716000MHZD2YT; Operating Mode: Fundamental; Online Catalog: ABM7 Series; Frequency Tolerance: ±20ppm; Frequency Stability: ±30ppm; Frequency: 16MHz; Featured Product: ABM7 and ABM11 Crystals; ESR (Equivalent Series Resistance): 50 Ohm; Catalog Drawings: ABM7 Series Top    ABM7 Series Side    ABM7 Series Bottom; 3D Model: ABM7.pdf    ABM7.stp; Type: MHz Crystal; Supplier Part Number 1: 535-9840-1-ND; Supplier 1: Digi-Key; Stock 1: 68132; Standard Package: 1,000; Size / Dimension: 0.236" L x 0.138" W (6.00mm x 3.50mm); Series: ABM7; RoHS Information: RoHS2/REACH Compliance; Pricing 1: 1=1, 10=0.886, 50=0.8278, 100=0.7315, 500=0.693 (USD); Packaging: Tape & Reel (TR); Package / Case: 2-SMD; Operating Temperature: -40°C ~ 85°C; Mounting Type: Surface Mount; Manufacturer Part Number: ABM7-16.000MHZ-D2Y-T; Load Capacitance: 18pF; Library Name: Arduino_V01.SchLib; Height: 0.055" (1.40mm); Family: Crystals; ComponentLink6URL: http://www.abracon.com/Support/PackageDrawing/Resonators/ABM7.PDF?src-supplier=Verical; ComponentLink6Description: http://www.abracon.com/Support/PackageDrawing/Resonators/ABM7.PDF?src-supplier=Verical; ComponentLink5URL: https://abracon.com/Resonators/abm7.pdf?src-supplier=Digi-Key; ComponentLink5Description: https://abracon.com/Resonators/abm7.pdf?src-supplier=Digi-Key; ComponentLink4URL: http://www.abracon.com/Resonators/abm7.pdf?src-supplier=Future+Electronics; ComponentLink4Description: http://www.abracon.com/Resonators/abm7.pdf?src-supplier=Future+Electronics; ComponentLink3URL: http://www.abracon.com/Resonators/abm7.pdf?src-supplier=Farnell; ComponentLink3Description: http://www.abracon.com/Resonators/abm7.pdf?src-supplier=Farnell; ComponentLink2URL: http://www.farnell.com/datasheets/947971.pdf?src-supplier=Element14; ComponentLink2Description: http://www.farnell.com/datasheets/947971.pdf?src-supplier=Element14; ComponentLink1URL: https://www.verical.com/server-webapp/html/getDatasheet?partID=721624&src-supplier=Verical; ComponentLink1Description: https://www.verical.com/server-webapp/html/getDatasheet?partID=721624&src-supplier=Verical; Component Kind: Standard; Category: Crystals and Oscillators
Z1 Z2 = CGA0603MLC-05E: ESD Suppressor TVS Bi-Dir 5V Automotive 2-Pin Case 0603(1608Metric) T/R; Manufacturer: Bourns; SVHC: No SVHC (17-Dec-2015); Product Range: CGA06 Series; No. of Pins: 2Pins; Diode Case Style: 0603; Clamping Voltage Vc Max: 30V; Supplier Part Number 1: CGA0603MLC-05ECT-ND; Supplier 1: Digi-Key; Stock 1: 4653; Pricing 1: 1=0.44, 10=0.367, 25=0.3208, 100=0.275, 250=0.2384, 500=0.2017, 1000=0.1558, 2500=0.1421 (USD); Packaging: Cut Tape; Operating Voltage: 5V; Manufacturer Part Number: CGA0603MLC-05E; Library Name: Arduino_V01.SchLib; ComponentLink3URL: http://www.bourns.com/data/global/pdfs/CGA-MLC.pdf?src-supplier=Avnet; ComponentLink3Description: http://www.bourns.com/data/global/pdfs/CGA-MLC.pdf?src-supplier=Avnet; ComponentLink2URL: http://www.farnell.com/datasheets/1772768.pdf?src-supplier=Element14; ComponentLink2Description: http://www.farnell.com/datasheets/1772768.pdf?src-supplier=Element14; ComponentLink1URL: http://www.mouser.com/ds/2/54/Bourns_CGA_MLC-280156.pdf?src-supplier=Mouser; ComponentLink1Description: http://www.mouser.com/ds/2/54/Bourns_CGA_MLC-280156.pdf?src-supplier=Mouser; Component Kind: Standard
